# Altium schematic sheet SensWear_Temperature.SchDoc
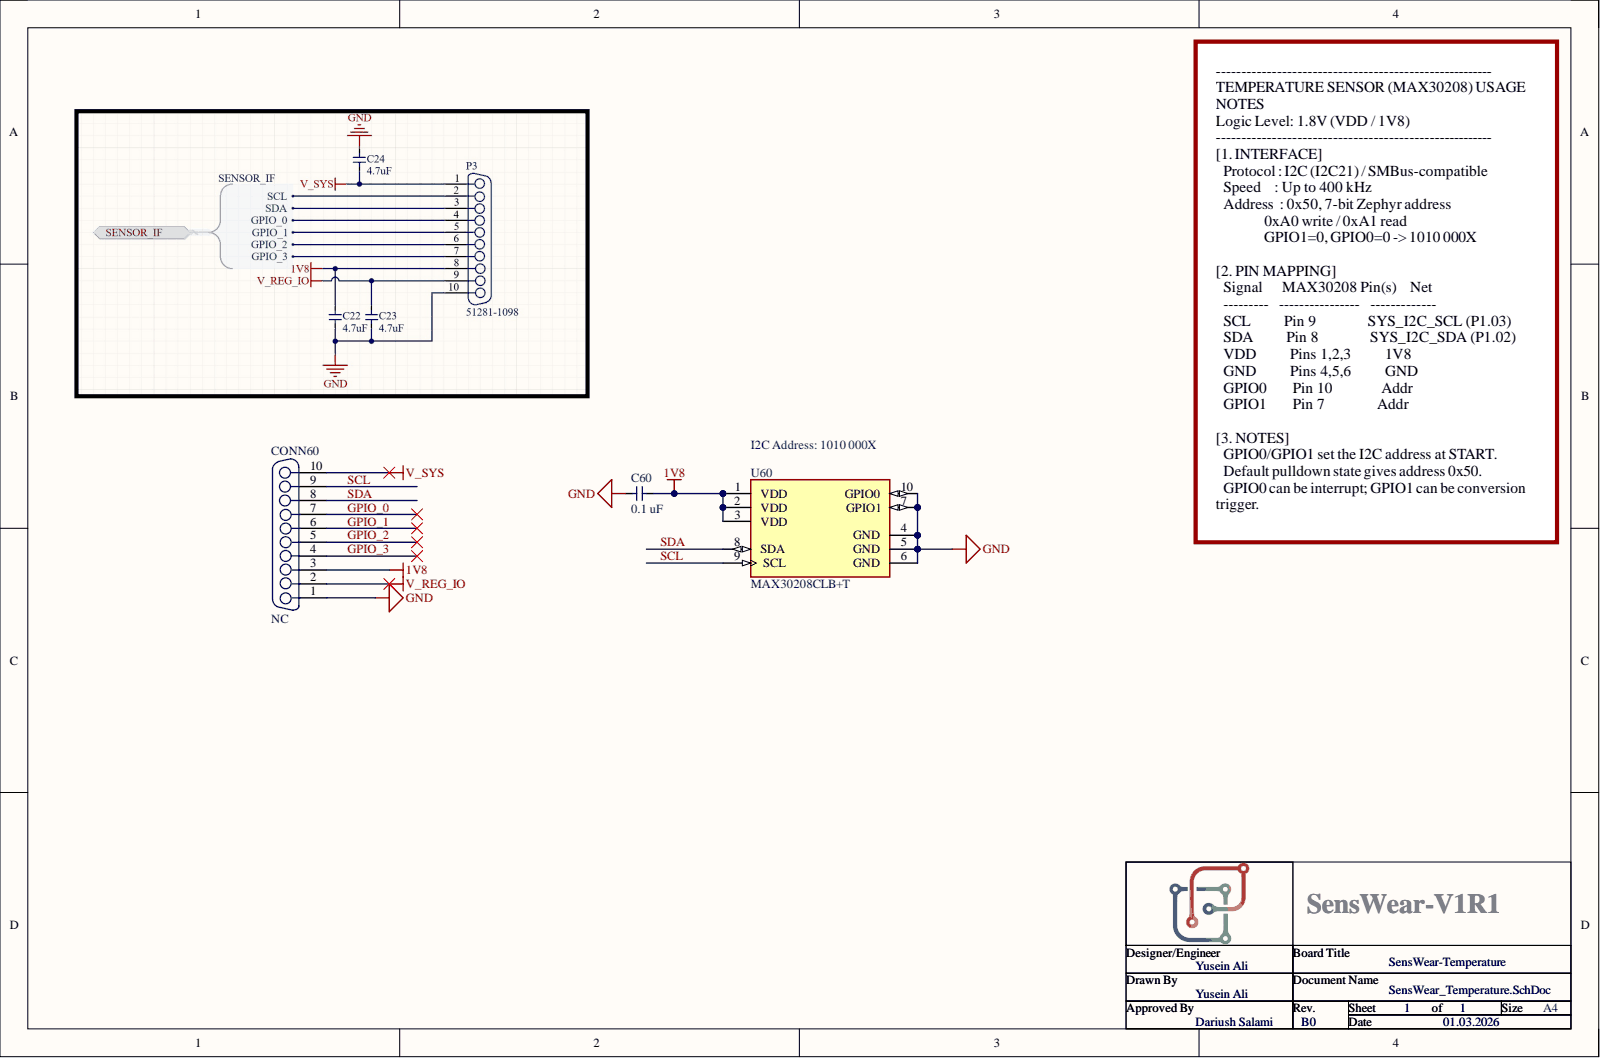
[diagram p0: SensWear_Temperature.SchDoc]

## Parts
C60  0.1 uF  [CAPC1005X05N]
CONN60  NC  [51281-1098-PCB]
U60  MAX30208CLB+T  [FP-L1022-2-MFG]

## Nets
1V8: C60.1 CONN60.3 U60.1(VDD) U60.2(VDD) U60.3(VDD)
GND: C60.2 CONN60.1 U60.4(GND) U60.5(GND) U60.6(GND) U60.7(GPIO1) U60.10(GPIO0)
V_REG_IO: CONN60.2
V_SYS: CONN60.10
GPIO_0: CONN60.7
GPIO_1: CONN60.6
GPIO_2: CONN60.5
GPIO_3: CONN60.4
SCL: CONN60.9 U60.9(SCL)
SDA: CONN60.8 U60.8(SDA)

## Library data of the parts
C60 = GRM155R61C104KA88D: CAP CER 0.1UF 16V X5R 0402; Brand: Murata Electronics; Capacitance - nF: 100 nF; Capacitance - pF: 100000 pF; Tolerance: 10 %; Type: Chip Multilayer Ceramic Capacitor for General Purpose; Voltage Rating DC: 16 VDC; Capacitance: 0.1 uF; Case Code - in: 0402; Case Code - mm: 1005; Category: Multilayer Ceramic Capacitors MLCC - SMD/SMT; Component Kind: Standard; ComponentLink1Description: http://www.mouser.com/datasheet/2/281/murata_03052018_GRM_Series_1-1310166.pdf; ComponentLink1URL: http://www.mouser.com/datasheet/2/281/murata_03052018_GRM_Series_1-1310166.pdf; Dielectric: X5R; Height: 0.5 mm; Length: 1 mm; Manufacturer: Murata Electronics; Manufacturer Part Number: GRM155R61C104KA88D; Maximum Operating Temperature: + 85 C; Minimum Operating Temperature: - 55 C; Minimum Order Quantity: 1; Package / Case: 0402 (1005 metric); Packaging: Cut Tape; Pricing: 1=0.082, 10=0.026, 100=0.011, 500=0.008, 1000=0.007, 2500=0.006, 5000=0.005, 10000=0.004 (EUR); Product: General Type MLCCs; Reeling: True; RoHS: RoHS Compliant; RoHS - Mouser: Y; Series: GRM; Standard Pack Qty: 10000; Stock: 0; Supplier 1: Mouser; Supplier 2: Digikey; Supplier 3: Digikey; Supplier Part Number 1: 81-GRM155R61C104KA8D; Supplier Part Number 2: 490-5415-1-ND; Supplier Part Number 3: 490-5415-6-ND; Termination: Standard; Termination Style: SMD/SMT; Value: 0.1 uF; Width: 0.5 mm; Library Name: SensWearComponents.IntLib
CONN60 = 51281-1098-PCB: System: Connector; Component Kind: Standard; Library Name: SensWearComponents.IntLib
U60 = MAX30208CLB+T: I2C Digital Temperature Sensor Serial I2C 10-Pin Thin LGA T/R; Manufacturer: Maxim; Checked: FALSE; Category: Temperature and Humidity Sensors; Component Kind: Standard; Manufacturer Part Number: MAX30208CLB+T; Pricing: 1=3.7, 10=2.9, 50=2.9, 100=2.69, 1000=2.11, 10000=1.97 (USD); RoHS: Yes; Stock: 16152; Supplier: Mouser; Supplier 1: Mouser; Supplier 2: Digikey; Supplier 3: Digikey; Supplier Part Number: 700-MAX30208CLB+T; Supplier Part Number 1: 700-MAX30208CLB+T; Supplier Part Number 2: 175-MAX30208CLB+TDKR-ND; Supplier Part Number 3: 175-MAX30208CLB+TTR-ND; Library Name: SensWearComponents.IntLib
